# Altium schematic sheet StroboscopeSheet.SchDoc(StroboscopeSheet)
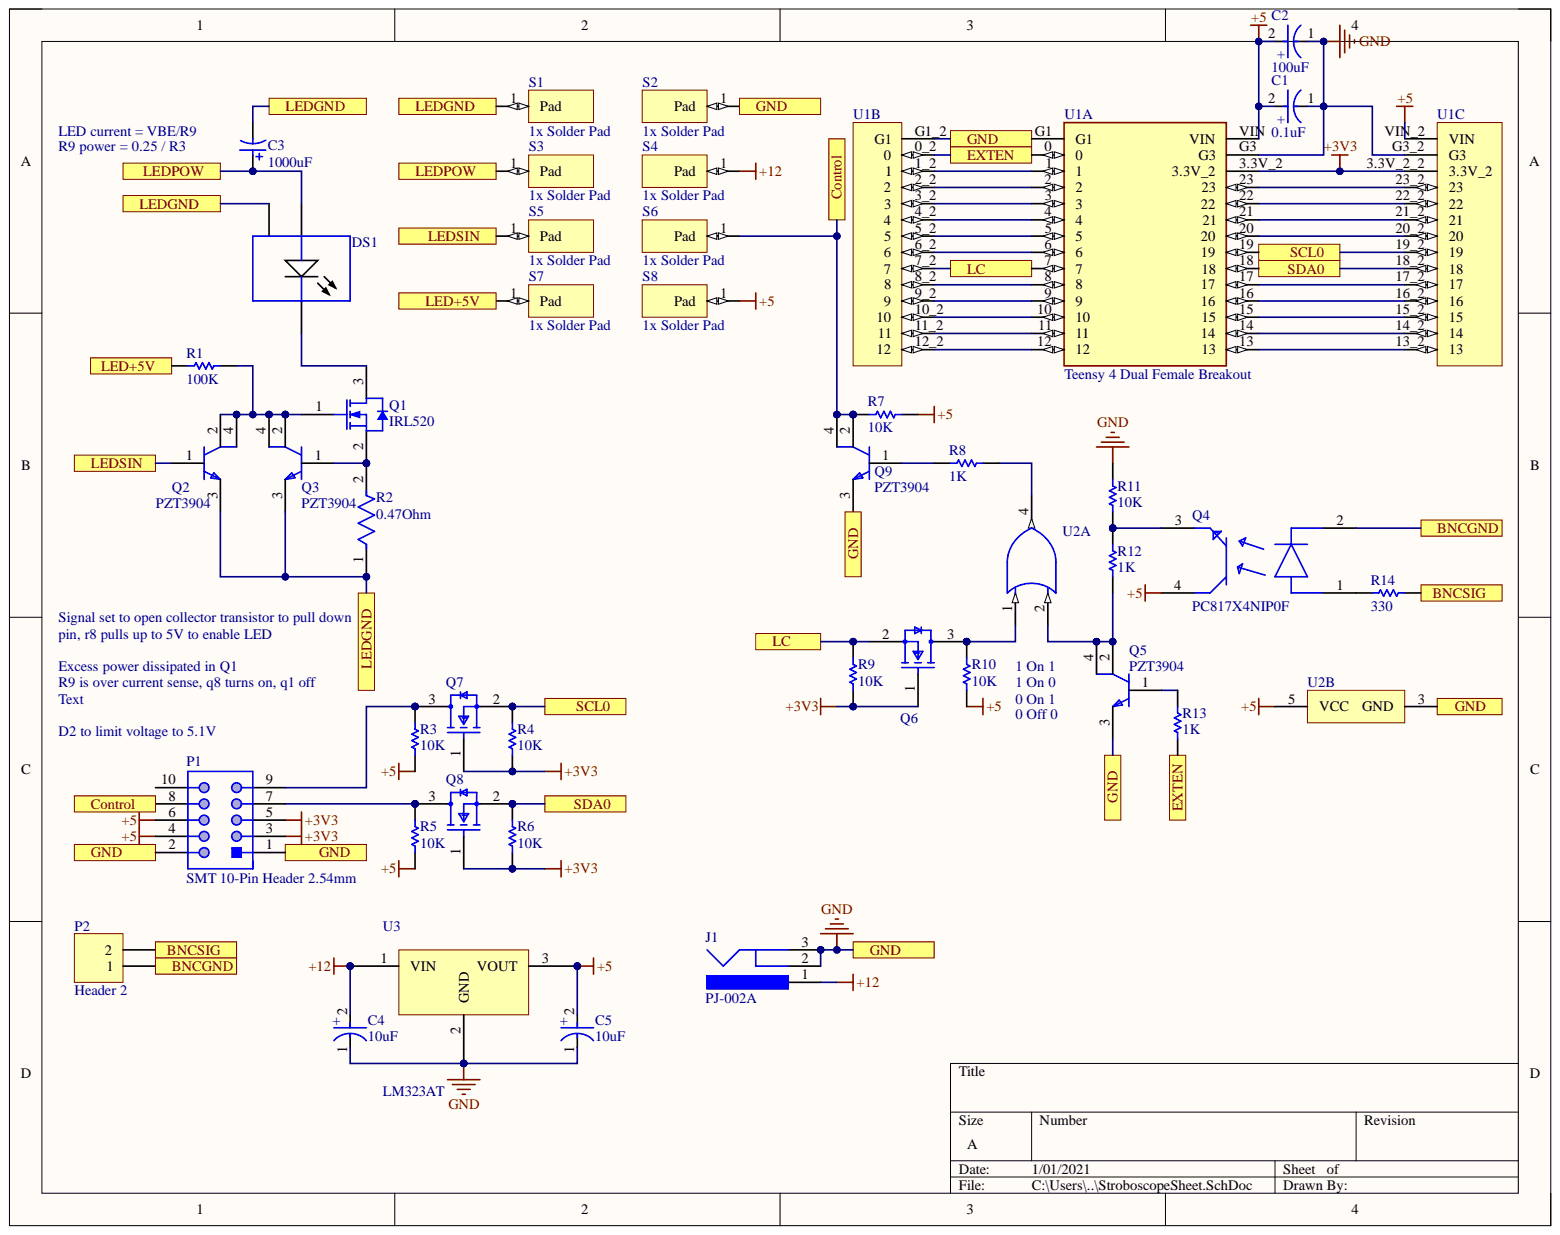
[diagram p0: StroboscopeSheet.SchDoc]

## Parts
C1  0.1uF  [FP-ZLG6_3X7-MFG]
C2  100uF  [FP-ZLG6_3X7-MFG]
C3  1000uF  [PNSC-EEUFM1H221-2]
C4  10uF  [FP-ZLG6_3X7-MFG]
C5  10uF  [FP-ZLG6_3X7-MFG]
DS1  MKRAWT-00-0000-0B00H40E5  [CREE-MK-R-2_V]  (value not drawn)
J1  PJ-002A  [CUI-PJ-002A_V]
P1  SMT 10-Pin Header 2.54mm  [SMT 10 Pin Header-Footprint-1]
P2  Header 2  [HDR1X2]
Q1  IRL520  [IRL520-Footprint-1]
Q2  PZT3904  [FP-318H-01-MFG]
Q3  PZT3904  [FP-318H-01-MFG]
Q4  PC817X4NIP0F  [FP-PC817X4NIP0F-MFG]
Q5  PZT3904  [FP-318H-01-MFG]
Q6  BSS138  [FP-318-08-IPC_B]  (value not drawn)
Q7  BSS138  [FP-318-08-IPC_B]  (value not drawn)
Q8  BSS138  [FP-318-08-IPC_B]  (value not drawn)
Q9  PZT3904  [FP-318H-01-MFG]
R1  100K  [RESC2013X50X30ML20T20]
R2  0.47Ohm  [FP-ERJ1TR-MFG]
R3  10K  [RESC2013X50X30ML20T20]
R4  10K  [RESC2013X50X30ML20T20]
R5  10K  [RESC2013X50X30ML20T20]
R6  10K  [RESC2013X50X30ML20T20]
R7  10K  [RESC2013X50X30ML20T20]
R8  1K  [RESC2013X50X30ML20T20]
R9  10K  [RESC2013X50X30ML20T20]
R10  10K  [RESC2013X50X30ML20T20]
R11  10K  [RESC2013X50X30ML20T20]
R12  1K  [RESC2013X50X30ML20T20]
R13  1K  [RESC2013X50X30ML20T20]
R14  330  [RESC2013X50X30ML20T20]
S1  1x Solder Pad  [Solder Pad-Footprint-1]
S2  1x Solder Pad  [Solder Pad-Footprint-1]
S3  1x Solder Pad  [Solder Pad-Footprint-1]
S4  1x Solder Pad  [Solder Pad-Footprint-1]
S5  1x Solder Pad  [Solder Pad-Footprint-1]
S6  1x Solder Pad  [Solder Pad-Footprint-1]
S7  1x Solder Pad  [Solder Pad-Footprint-1]
S8  1x Solder Pad  [Solder Pad-Footprint-1]
U1  Teensy 4 Dual Female Breakout  [Teensy 4 Dual Female Breakout-Footprint-1]  (parts A,B,C)
U2  SN74LVC1G32DCKR  [DCK0005A_N]  (value not drawn)  (parts A,B)
U3  LM323AT  [New footprint 1]

## Ports
BNCGND, BNCSIG, Control, EXTEN, GND, LC, LED+5V, LEDGND, LEDPOW, LEDSIN, SCL0, SDA0

## Nets
+3V3: P1.3 P1.5 Q6.1 Q7.1 Q8.1 R4.1 R6.1 R9.1 U1.3.3V_2 U1.3.3V_2_2(3.3V_2)
+5: C1.2 C2.2 C5.2 P1.4 P1.6 Q4.4 R3.1 R5.1 R7.1 R10.1 S8.1 U1.VIN U1.VIN_2(VIN) U2.5(VCC) U3.3
+12: C4.2 J1.1 S4.1 U3.1
BNCGND: P2.1 Q4.2 ; port BNCGND
BNCSIG: P2.2 R14.2 ; port BNCSIG
Control: P1.8 Q9.2 Q9.4 R7.2 S6.1 ; port Control
EXTEN: R13.2 U1.0 U1.0_2 ; port EXTEN
GND: C1.1 C2.1 C4.1 C5.1 J1.2 J1.3 P1.1 P1.2 Q5.3 Q9.3 R11.1 S2.1 U1.G1 U1.G1_2(G1) U1.G3 U1.G3_2(G3) U2.3(GND) U3.2 ; port GND
LC: Q6.2 R9.2 U1.7 U1.7_2 ; port LC
LED+5V: R1.1 S7.1 ; port LED+5V
LEDGND: C3.2 DS1.3 Q2.3 Q3.3 R2.1 S1.1 ; port LEDGND
LEDPOW: C3.1 DS1.1 S3.1 ; port LEDPOW
LEDSIN: Q2.1 S5.1 ; port LEDSIN
SCL0: Q7.2 R4.2 U1.19 U1.19_2 ; port SCL0
SDA0: Q8.2 R6.2 U1.18 U1.18_2 ; port SDA0
NetDS1_2: DS1.2 Q1.3
NetP1_7: P1.7 Q8.3 R5.2
NetP1_9: P1.9 Q7.3 R3.2
NetQ1_1: Q1.1 Q2.2 Q2.4 Q3.2 Q3.4 R1.2
NetQ1_2: Q1.2 Q3.1 R2.2
NetQ4_1: Q4.1 R14.1
NetQ4_3: Q4.3 R11.2 R12.1
NetQ5_1: Q5.1 R13.1
NetQ5_2: Q5.2 Q5.4 R12.2 U2.2
NetQ6_3: Q6.3 R10.2 U2.1
NetQ9_1: Q9.1 R8.2
NetR8_1: R8.1 U2.4
NetU1_1: U1.1 U1.1_2
NetU1_2: U1.2 U1.2_2
NetU1_3: U1.3 U1.3_2
NetU1_4: U1.4 U1.4_2
NetU1_5: U1.5 U1.5_2
NetU1_6: U1.6 U1.6_2
NetU1_8: U1.8 U1.8_2
NetU1_9: U1.9 U1.9_2
NetU1_10: U1.10 U1.10_2
NetU1_11: U1.11 U1.11_2
NetU1_12: U1.12 U1.12_2
NetU1_13: U1.13 U1.13_2
NetU1_14: U1.14 U1.14_2
NetU1_15: U1.15 U1.15_2
NetU1_16: U1.16 U1.16_2
NetU1_17: U1.17 U1.17_2
NetU1_20: U1.20 U1.20_2
NetU1_21: U1.21 U1.21_2
NetU1_22: U1.22 U1.22_2
NetU1_23: U1.23 U1.23_2

Not connected: P1 10

## Library data of the parts
C1 C2 C4 C5 = CMP-35376-000005-1: CAP ALUM 47UF 20% 25V RADIAL; Manufacturer: Rubycon; Manufacturer Part Number: 25ZLG47MEFC6.3X7; Dissipation Factor: 14%; Leakage Current: 35.25uA; Life: 1000Hours; Material: Aluminum; Ripple Current: 480mA; Automotive: No; Capacitance: 47uF; Category: Cap; Component Kind: Standard; ComponentLink2Description: Datasheet; ComponentLink2URL: http://www.rubycon.co.jp/en/catalog/e_pdfs/aluminum/e_ZLG.pdf; Device Class L1: Passive Components; Device Class L2: Capacitors; Device Class L3: Aluminum Electrolytic Capacitors; Digikey Description: CAP ALUM 47UF 20% 25V RADIAL; Height: 8mm; Ipc Land Pattern Name: CAPRD250W60D680H800; Lead Free: Yes; Library Name: Altium Content Vault; Octopart: https://octopart.com/25zlg47mefc6.3x7-rubycon-19941845; Package: ZLG6.3X7; RoHS: TRUE; Temperature: -40°C to +105°C; Temperature Range High: +105°C; Temperature Range Low: -40°C; Tolerance: 20%; Value: 47uF; Voltage Rating: 25V
C3 = CMP-2000-05313-1: Aluminum Electrolytic Capacitor, 1000 uF, +/- 20%, 16 V, -40 to 105 degC, 2-Pin THD, RoHS, Tape and Reel; Manufacturer: Panasonic; Capacitance: 1000µF; Impedance: 0.028O; Lifetime@Temperature: 8000Hrs@105degC; Rated Voltage: 16V; Ripple Current: 1790mA; Type: Radial; Case Size/Dimension: Ø10x16mm; Component Kind: Standard; Datasheet URL: http://www.produktinfo.conrad.com/datenblaetter/425000-449999/446746-da-01-en-ALU_KONDENSATOR_FR_R_1000_UF_16V.pdf; Datasheet Version: Nov-11; Library Name: Altium Content Vault; Manufacturer URL: http://www.panasonic.com/; Operating Temp Range: -40to+105degC; Operating Temp-Max: 105degC; Series: FR
DS1 = CMP-2100-00930-1: LED, White, 6 V, 2-Pin SMD, RoHS, Tape and Reel; Component Kind: Standard; Library Name: Altium Content Vault
J1 = CMP-2000-06895-1: Through Hole Right Angle DC Power Jack, 2.5 A, 2 mm Center Pin, 3 Position, -25 to 85 degC, RoHS, Bulk; Manufacturer: CUIInc.; Brand: CUI; Current Rating: 2.5A; Inside Contact Diameter: 2mm; Insulation Resistance: 50MOhms; Outside Contact Diameter: 6.5mm; Voltage Rating: 24V; Component Kind: Standard; Connector Type: DCPowerJack; Contact Gender: Male; Contact Material: Copper; Contact Plating: Nickel; Datasheet URL: http://www.cui.com/product/resource/pj-002a.pdf; Datasheet Version: Rev. 1.03, 04/2016; Factory Pack Quantity: 500; Flammability Rating: UL94V-0; Housing Material: PolybutyleneTerephthalate(PBT); Library Name: Altium Content Vault; Manufacturer URL: http://www.cui.com/; Mounting Angle: Right; Mounting Style: ThroughHole; Mounting Technology: ThroughHole; Operating Temperature Range: -25Cto+85C; Package Description: 3-Pin Through Hole Device, Body 14.4 x 9 mm, Height 11 mm; Package Reference: PJ-002A; Product: Jacks; Product Category: DCPowerConnectors; RoHS: True; Termination Style: ThroughHole
P1 = CMP-001-0001-1: Component Kind: Standard; Library Name: Gannon University
P2 = Header 2: Header, 2-Pin; Component Kind: Standard; LatestRevisionDate: 17-Jul-2002; LatestRevisionNote: Re-released for DXP Platform.; Library Name: Miscellaneous Connectors.IntLib; Signal Integrity: Connector; Publisher: Altium Limited
Q1 = CMP-013-00034-2: Hexfet 9.2A 100V TO-220 50PCS/TUBE; Rise Time: 64ns; Case/Package: TO-220; Component Kind: Standard; Current Rating: 9.2A; Drain to Source Breakdown Voltage: 100V; Lead Free: Contains Lead; Package Quantity: 1000; Packaging: Rail/Tube; Voltage Rating (DC): 100V; Library Name: Gannon University
Q2 Q3 Q5 Q9 = CMP-07170-000017-1: TRANS NPN 40V 0.2A SOT223; Manufacturer: ON Semiconductor; Obsolete Part: true; Automotive Grade: Grade 0; Collector Base Voltage: 60V; Collector Emitter Saturation Voltage: 0.3V; Delay Time Td: 35ns; Emitter Base Voltage: 6V; Fall Time Tf: 50ns; Gain Bandwidth Product: 300MHz; Hfe Max: 300; Hfe Min: 100; Hfe Ss Max: 400; Hfe Ss Testic: 1mA; Hfe Ss Testvce: 10V; Hfe Testic: 10mA; Hfe Testvce: 1V; Max Collector Current: 200mA; Max Junction Temp: +150°C; Number Of Elements: 1; Power Dissipation: 1.5W; Rthja Max: 83.3°C/W; Standoff Height: 0.02mm; Automotive: Yes; Category: Trans; Collector Emitter Breakdown Voltage: 40V; Collector Emitter Voltage: 40V; Component Kind: Standard; ComponentLink2Description: Datasheet; ComponentLink2URL: https://www.onsemi.com/pub/Collateral/PZT3904T1-D.PDF; Device Class L1: Discrete Semiconductors; Device Class L2: Transistors; Device Class L3: BJTs; Digikey Description: TRANS NPN 40V 0.2A SOT223; Footprint Url: https://www.onsemi.com/pub/Collateral/318H-01.PDF; Height: 1.8mm; Hfe Ss Min: 100; Ipc Land Pattern Name: SOT230P700X180-4; Lead Free: Yes; Library Name: Altium Content Vault; Manufacturer Part Number: PZT3904; Mouser Description: ON Semi PZT3904 NPN Transistor, 200 mA, 40 V, 3 + Tab-Pin SOT-223; Number Of Npn: 1; Octopart: https://octopart.com/pzt3904-on+semiconductor-7897311; Package: 318H-01; Rise Time Tr: 35ns; RoHS: TRUE; Storage Time Ts: 200ns; Temperature: -55°C to +150°C; Temperature Range High: +150°C; Temperature Range Low: -55°C; Unity Gain Freq: 300MHz
Q4 = CMP-65434-000001-1: OPTOISOLATOR 5KV TRANS 4SMD; Manufacturer: Sharp; Collector Emitter Voltage: 80V; Emiiter Collector Voltage: 6V; Forward Current: 20mA; Forward Voltage: 1.2V; Isolation Voltage: 5kVrms; Max Current Transfer Ratio: 600%; Min Current Transfer Ratio: 50%; Power Dissipation: 200mW; Standoff Height: 0.1mm; Automotive: No; Category: Trans; Component Kind: Standard; ComponentLink2Description: Datasheet; ComponentLink2URL: https://www.sharpsde.com/fileadmin/products/Optoelectronics/Isolation%20Devices/Specs%20Photocoupler/PC817XxNIP0F_17Jun08_Spec_ED-04P129A.pdf; Device Class L1: Optoelectronics; Device Class L2: Optocouplers; Device Class L3: unset; Digikey Description: OPTOISOLATOR 5KV TRANS 4SMD; Height: 4.6mm; Lead Free: Yes; Library Name: Altium Content Vault; Manufacturer Part Number: PC817X4NIP0F; Mouser Description: Transistor Output Optocouplers Photocoupler 300-600% CRT; Octopart: https://octopart.com/pc817x4nip0f-sharp-20650453; Package: PC817X4NIP0F; Reverse Voltage: 6V; RoHS: TRUE; Temperature: -30°C to +100°C; Temperature Range High: +100°C; Temperature Range Low: -30°C
Q6-Q8 = CMP-07173-000101-1: MOSFET N-CH 50V 220MA SOT-23; Drain to Source Resistance: 3.5R; Element Configuration: Single; Fall Time: 9ns; Gate to Source Voltage (Vgs): 20V; Input Capacitance: 27pF; Max Power Dissipation: 360mW; Nominal Vgs: 1.3V; Number of Elements: 1; On-State Resistance: 6R; Turn-Off Delay Time: 20ns; Turn-On Delay Time: 2.5ns; Case/Package: SOT-23; Component Kind: Standard; Contact Plating: Tin; Continuous Drain Current (ID): 220mA; Current Rating: 220mA; Drain to Source Breakdown Voltage: 50V; Drain to Source Voltage (Vdss): 50V; Dual Supply Voltage: 50V; Height: 0.93mm; Lead Free: Lead Free; Length: 2.92mm; Library Name: Altium Content Vault; Max Operating Temperature: 150°C; Min Operating Temperature: -55°C; Mount: Surface Mount; Number of Pins: 3; Package Quantity: 1; Packaging: Tape and Reel; Power Dissipation: 360mW; Radiation Hardening: No; Rds On Max: 3.5R; REACH SVHC: No SVHC; Rise Time: 9ns; Termination: SMD/SMT; Threshold Voltage: 1.3V; Voltage Rating (DC): 50V; Weight: 0.03g; Width: 1.3mm
R1 R3-R14 = CMP-2001-03735-1: Manufacturer: Vishay Dale; Part Number: CRCW0805270RFKEA; Component Kind: Standard; Library Name: Altium Content Vault
R2 = CMP-05422-000060-1: RES 0.22 OHM 1% 1W 2512; 3DS Part Number: 110-00006; Manufacturer: Panasonic; Manufacturer Part Number: ERJ-1TRQFR22U; Automotive Grade: Grade 0; Resistance: 0.22Ω; Temperature Coefficient: 200ppm/°C; Value: 0.22Ω; Automotive: Yes; Category: Res; Component Kind: Standard; ComponentLink2Description: Datasheet; ComponentLink2URL: https://industrial.panasonic.com/cdbs/www-data/pdf/RDN0000/AOA0000C313.pdf; Composition: Thick Film; Device Class L1: Passive Components; Device Class L2: Resistors; Device Class L3: Chip SMD Resistors; Digikey Description: RES 0.22 OHM 1% 1W 2512; Footprint Url: https://industrial.panasonic.com/cdbs/www-data/pdf/RDN0000/DMN0000COL17.pdf; Height: 0.7mm; Ipc Land Pattern Name: RESC640320X60; Lead Free: Yes; Library Name: Altium Content Vault; Mouser Description: Current Sense Resistors 2512 0.22ohm 1% Curr Sense AEC-Q200; Octopart: https://octopart.com/erj1trqfr22u-panasonic-10566390; Package: ERJ1TR; Power Rating: 1W; RoHS: TRUE; Series: ERJ; Temperature: -55°C to +155°C; Temperature Range High: +155°C; Temperature Range Low: -55°C; Tolerance: 1%
S1-S8 = CMP-00000-2: 3mmx4mm Solder Pad; Component Kind: Standard; Library Name: Gannon University
U1 = CMP-016-0001-2: Teensy 4.0 Microcontroller layout for dual-female SMT headers; Component Kind: Standard; Library Name: Gannon University
U2 = CMP-0859-00376-3: Single 2-Input Positive-OR Gate, DCK0005A, LARGE T&R; Manufacturer: Texas Instruments; 3-State Output: No; Bits(#): 1; F @ Nom Voltage(Max)(Mhz): 150; ICC @ Nom Voltage(Max)(mA): 0.01; Input Type: CMOS/TTL; Logic: True; Output Drive (IOL/IOH)(Max)(mA): 32/-32; Output Type: CMOS; Rating: Catalog; Sub-Family: OR Gate; Technology Family: LVC; tpd @ Nom Voltage(Max)(ns): 7.2,4.4,3.6,3.4; VCC(Max)(V): 5.5; VCC(Min)(V): 1.65; Voltage(Nom)(V): 1.8,2.5,3.3,5; Component Kind: Standard; DatasheetVersion: SCES219U; Generic Part Number: SN74LVC1G32; Library Name: Altium Content Vault; Operating Temperature Range(C): -40 to 125,-40 to 85; PackageReference: DCK0005A; Schmitt Trigger: No
U3 = CMP-001-0000-3: IC REG LINEAR 5V 3A TO220AB; Number of Regulators: 1; Case/Package: TO-220-3; Component Kind: Standard; Lead Free: Contains Lead; Max Operating Temperature: 125°C; Min Operating Temperature: 0°C; Mount: Through Hole; Output Current: 3A; Output Voltage: 5V; Package Quantity: 50; Packaging: Rail/Tube; Library Name: Gannon University
